# Altium schematic sheet TinyBeacon-144-RevD.SchDoc
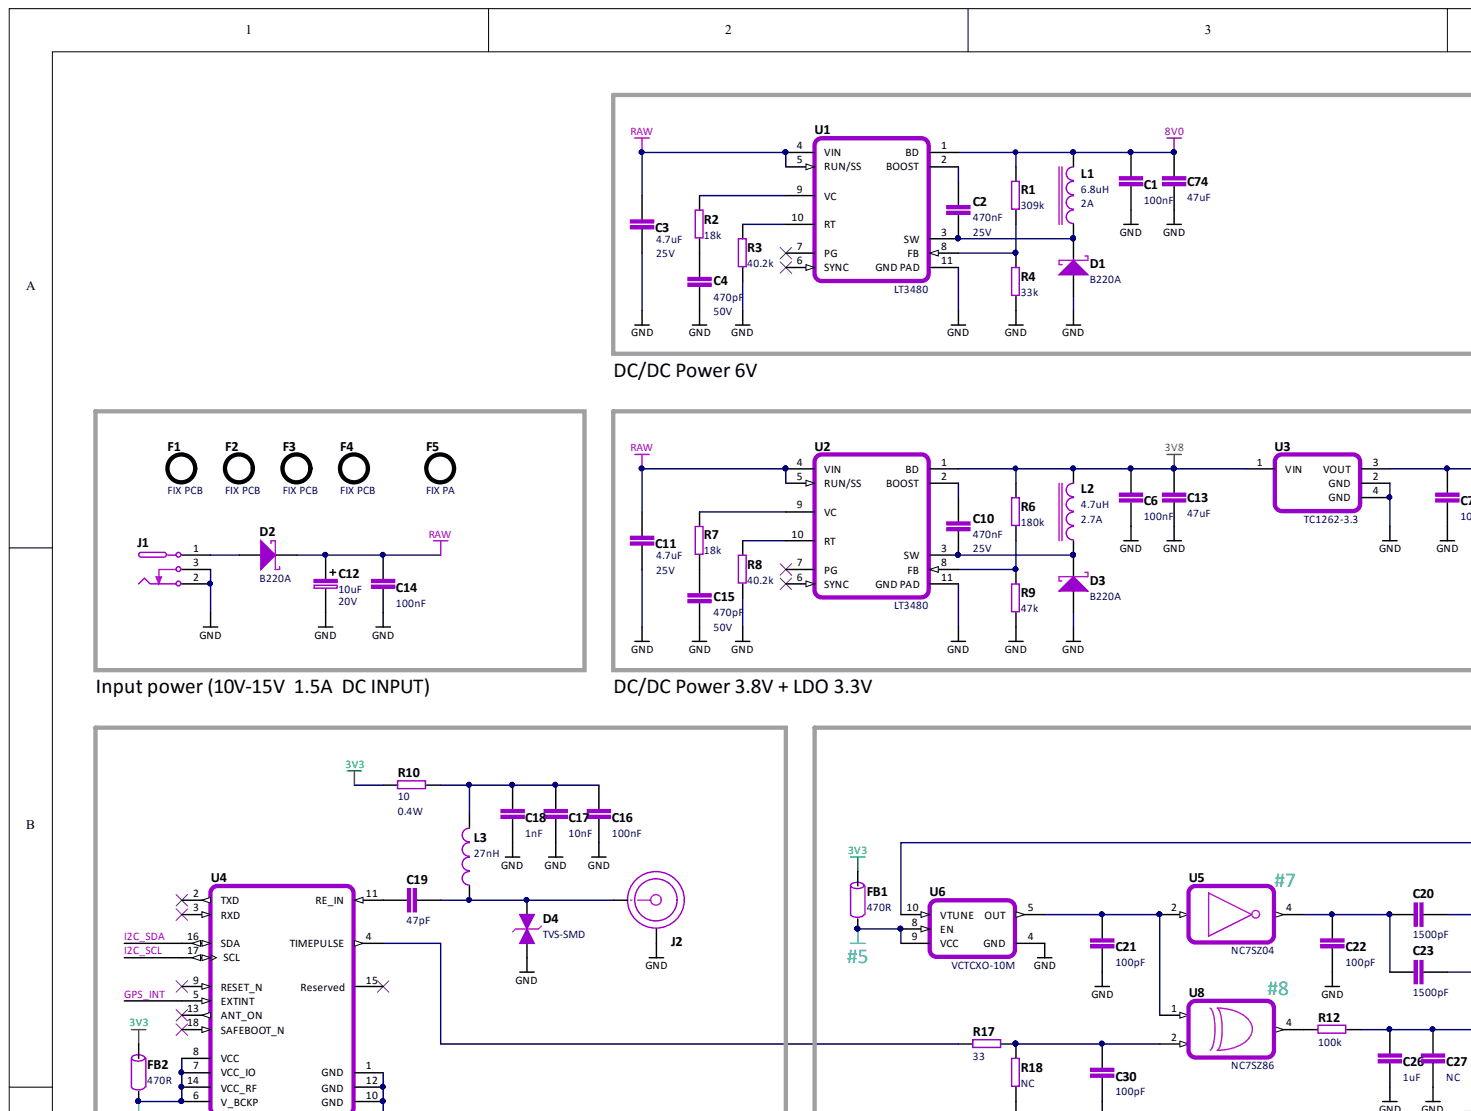
[diagram p0: TinyBeacon-144-RevD.SchDoc, top left]
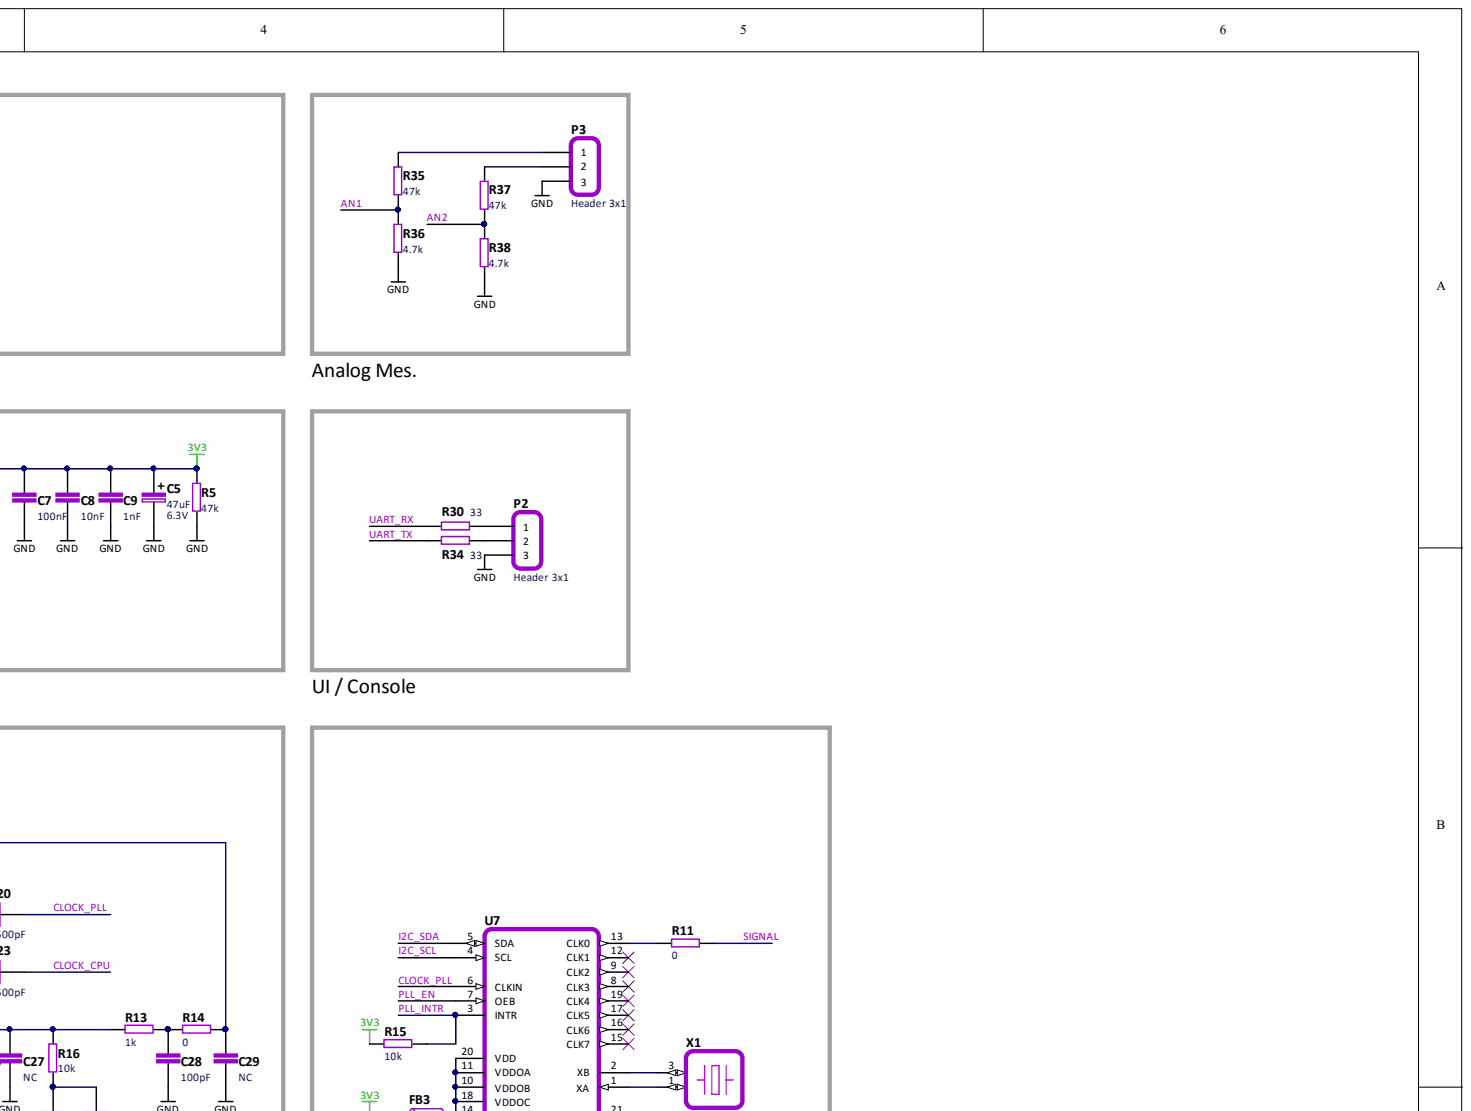
[diagram p1: TinyBeacon-144-RevD.SchDoc, top right]
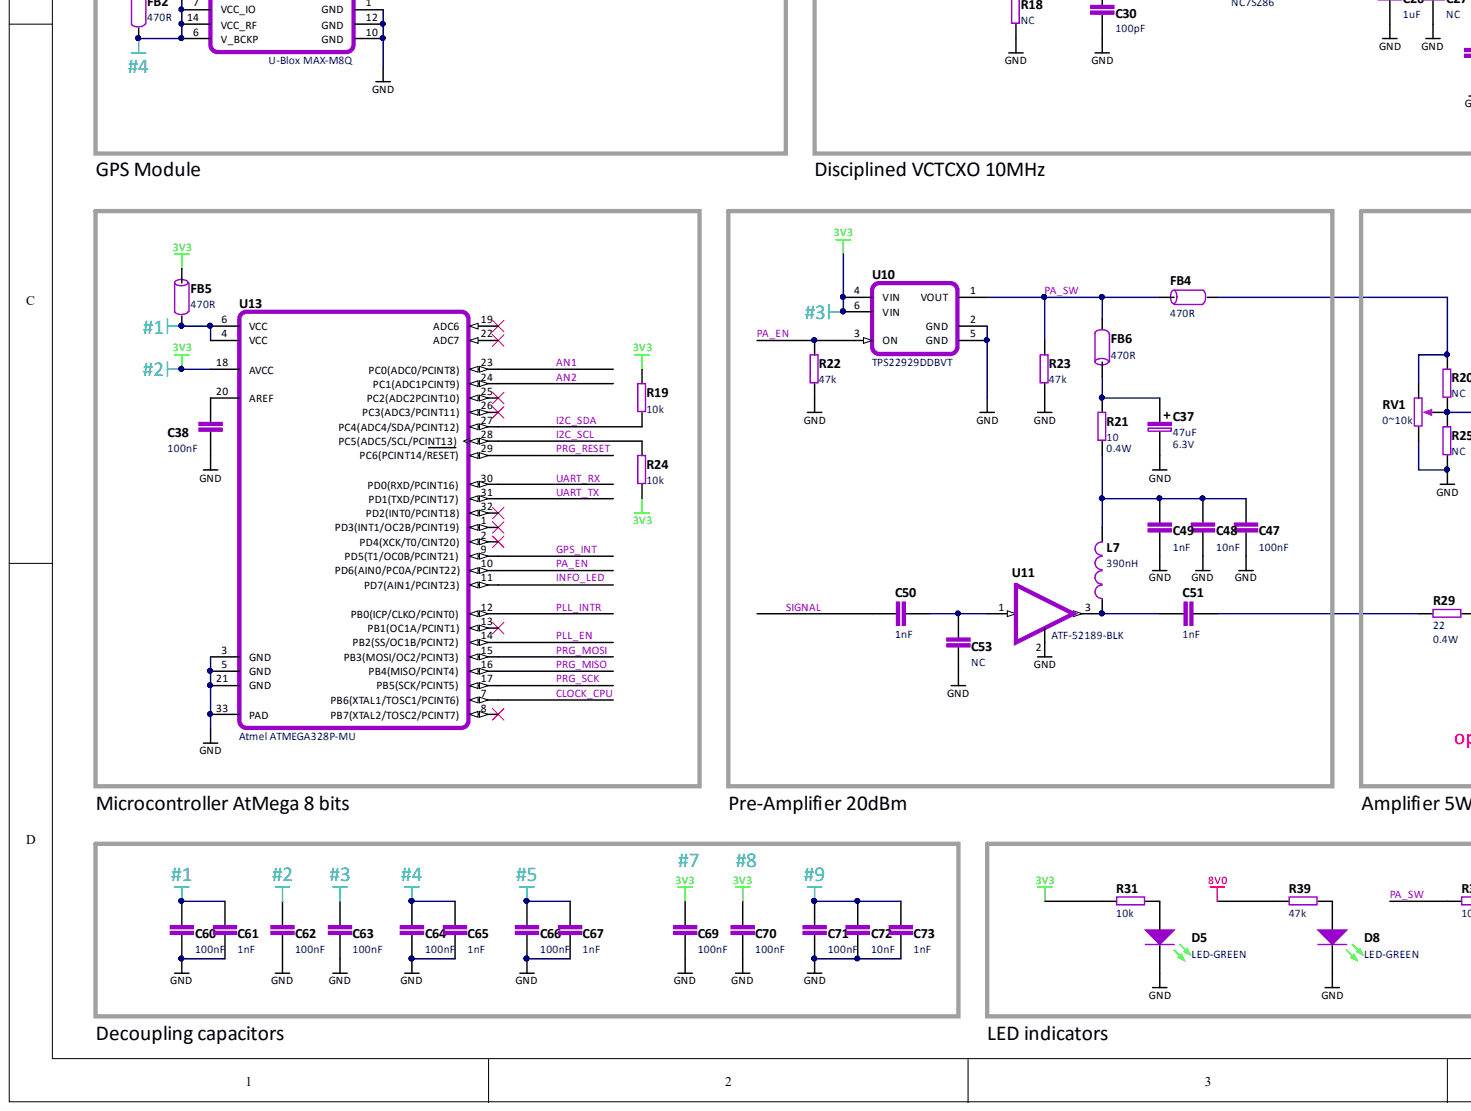
[diagram p2: TinyBeacon-144-RevD.SchDoc, bottom left]
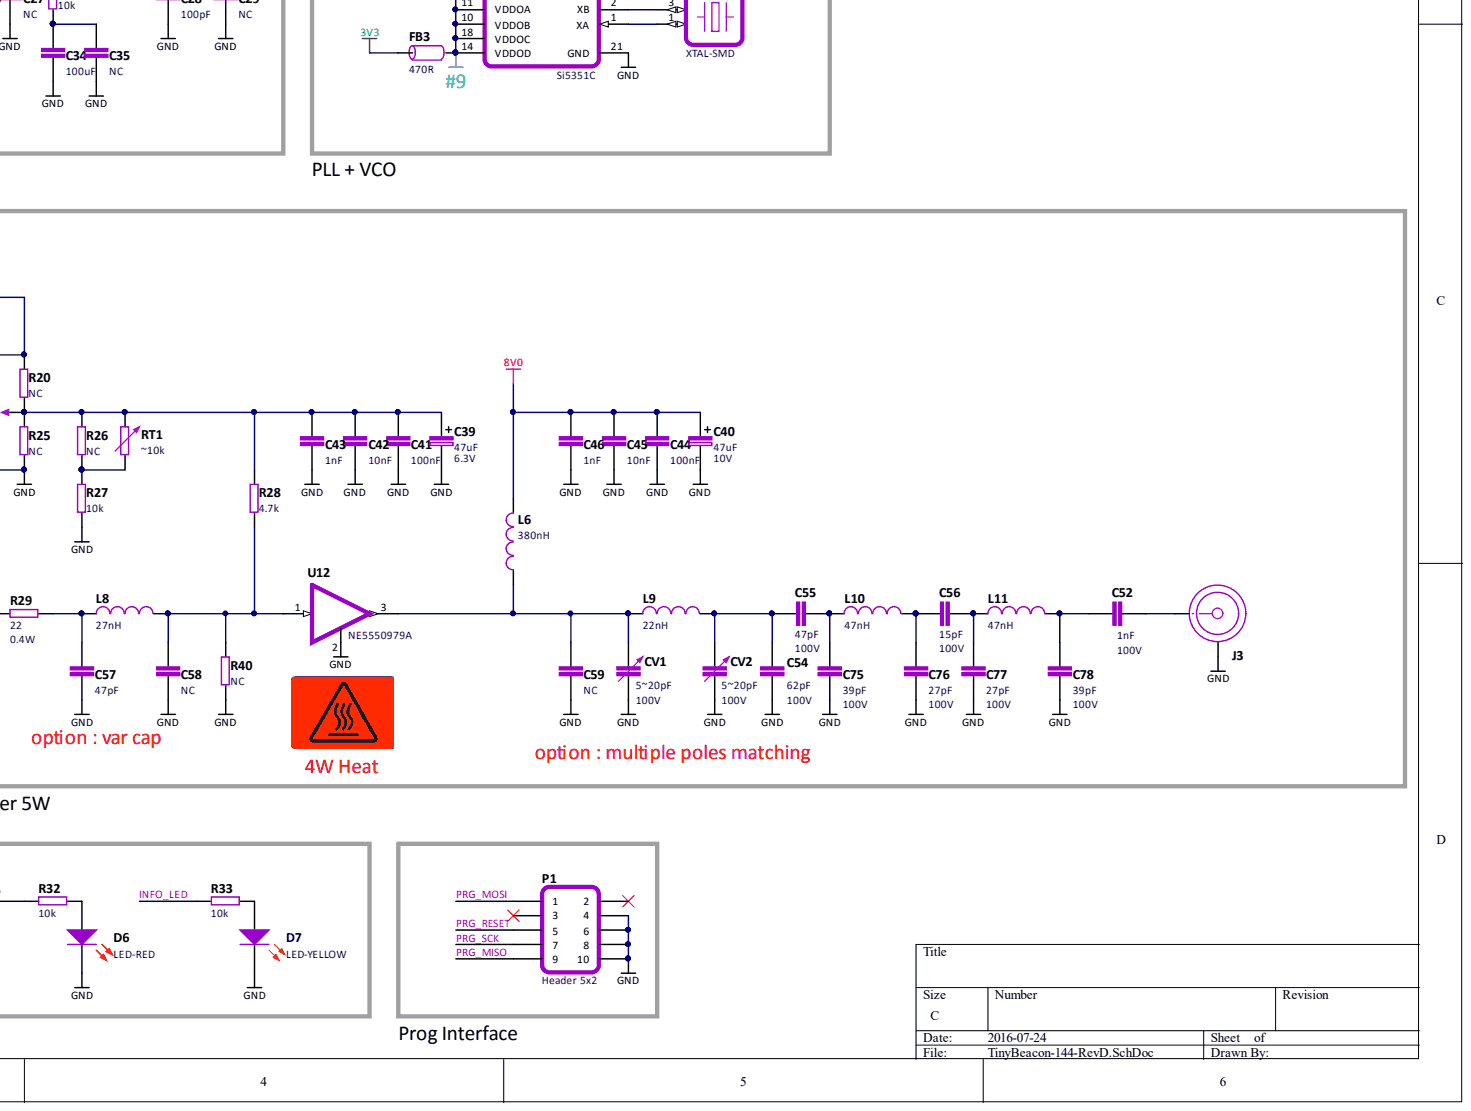
[diagram p3: TinyBeacon-144-RevD.SchDoc, bottom right]

## Parts
C1  100nF  [PCB-1608]
C2  470nF  Rated Voltage: 25V  [PCB-1608]
C3  4.7uF  Rated Voltage: 25V  [PCB-2012]
C4  470pF  Rated Voltage: 50V  [PCB-1608]
C5  47uF  Rated Voltage: 6.3V  [CAP-POL-3216]
C6  100nF  [PCB-1608]
C7  100nF  [PCB-1608]
C8  10nF  [PCB-1608]
C9  1nF  [PCB-1608]
C10  470nF  Rated Voltage: 25V  [PCB-1608]
C11  4.7uF  Rated Voltage: 25V  [PCB-2012]
C12  10uF  Rated Voltage: 20V  [CAP-POL-3216]
C13  47uF  [PCB-3216]
C14  100nF  [PCB-1608]
C15  470pF  Rated Voltage: 50V  [PCB-1608]
C16  100nF  [PCB-1608]
C17  10nF  [PCB-1608]
C18  1nF  [PCB-1608]
C19  47pF  [PCB-1608]
C20  1500pF  [PCB-1608]
C21  100pF  [PCB-1608]
C22  100pF  [PCB-1608]
C23  1500pF  [PCB-1608]
C26  1uF  [PCB-1608]
C27  NC  [PCB-2012]
C28  100pF  [PCB-1608]
C29  NC  [PCB-1608]
C30  100pF  [PCB-1608]
C34  100uF  Rated Current: NC  [PCB-2012]
C35  NC  [PCB-1608]
C37  47uF  Rated Voltage: 6.3V  [CAP-POL-3216]
C38  100nF  [PCB-1608]
C39  47uF  Rated Voltage: 6.3V  [CAP-POL-3216]
C40  47uF  Rated Voltage: 10V  [CAP-POL-3216]
C41  100nF  [PCB-1608]
C42  10nF  [PCB-1608]
C43  1nF  [PCB-1608]
C44  100nF  [PCB-1608]
C45  10nF  [PCB-1608]
C46  1nF  [PCB-1608]
C47  100nF  [PCB-1608]
C48  10nF  [PCB-1608]
C49  1nF  [PCB-1608]
C50  1nF  [PCB-1608]
C51  1nF  [PCB-1608]
C52  1nF  Rated Voltage: 100V  [PCB-1608]
C53  NC  [PCB-1608]
C54  62pF  Rated Voltage: 100V  [PCB-1608]
C55  47pF  [PCB-1608]
C56  15pF  [PCB-1608]
C57  47pF  [PCB-1608]
C58  NC  [PCB-1608]
C59  NC  [PCB-1608]
C60  100nF  [PCB-1608]
C61  1nF  [PCB-1608]
C62  100nF  [PCB-1608]
C63  100nF  [PCB-1608]
C64  100nF  [PCB-1608]
C65  1nF  [PCB-1608]
C66  100nF  [PCB-1608]
C67  1nF  [PCB-1608]
C69  100nF  [PCB-1608]
C70  100nF  [PCB-1608]
C71  100nF  [PCB-1608]
C72  10nF  [PCB-1608]
C73  1nF  [PCB-1608]
C74  47uF  [PCB-3216]
C75  39pF  Rated Voltage: 100V  [PCB-1608]
C76  27pF  Rated Voltage: 100V  [PCB-1608]
C77  27pF  Rated Voltage: 100V  [PCB-1608]
C78  39pF  Rated Voltage: 100V  [PCB-1608]
CV1  5~20pF  Rated Voltage: 100V  [PCB-TZC3]
CV2  5~20pF  Rated Voltage: 100V  [PCB-TZC3]
D1  B220A  [D-SMA]
D2  B220A  [D-SMA]
D3  B220A  [D-SMA]
D4  TVS-SMD  [PCB-1005]
D5  LED-GREEN  [PCB-LED-2012]
D6  LED-RED  [PCB-LED-2012]
D7  LED-YELLOW  [PCB-LED-2012]
D8  LED-GREEN  [PCB-LED-2012]
F1  FIX PCB  Category: PCB  [PCB-FIX-ROUND]
F2  FIX PCB  Category: PCB  [PCB-FIX-ROUND]
F3  FIX PCB  Category: PCB  [PCB-FIX-ROUND]
F4  FIX PCB  Category: PCB  [PCB-FIX-ROUND]
F5  FIX PA  [PCB-FIX-ROUND]
FB1  470R  [PCB-2012]
FB2  470R  [PCB-2012]
FB3  470R  [PCB-2012]
FB4  470R  [PCB-2012]
FB5  470R  [PCB-2012]
FB6  470R  [PCB-2012]
J1  CUI PJ-002AH-SMT-TR  [PCB-CUI-PJ-002AH-SMT]  (value not drawn)
J2  J502 Connector  [PCB-SMA]  (value not drawn)
J3  J502 Connector  [PCB-SMA]  (value not drawn)
L1  6.8uH  Rated Current: 2A  [PCB-5650]
L2  4.7uH  Rated Current: 2.7A  [PCB-5650]
L3  27nH  [PCB-2012]
L6  380nH  [PCB-IND-AIAC-4125]
L7  390nH  [PCB-3216]
L8  27nH  [PCB-2012]
L9  22nH  [PCB-IND-AIAC-1812]
L10  47nH  [PCB-IND-AIAC-1812]
L11  47nH  [PCB-IND-AIAC-1812]
P1  Header 5x2  [PCB-HDR2X5-SMD]
P2  Header 3x1  [PCB-0rhklu08i7n9dxwvdgja-1]
P3  Header 3x1  [PCB-0rhklu08i7n9dxwvdgja-1]
R1  309k  [PCB-1608]
R2  18k  [PCB-1608]
R3  40.2k  [PCB-1608]
R4  33k  [PCB-1608]
R5  47k  [PCB-1608]
R6  180k  [PCB-1608]
R7  18k  [PCB-1608]
R8  40.2k  [PCB-1608]
R9  47k  [PCB-1608]
R10  10  Rated Power: 0.4W  [PCB-2012]
R11  0  [PCB-1608]
R12  100k  [PCB-1608]
R13  1k  [PCB-1608]
R14  0  [PCB-1608]
R15  10k  [PCB-1608]
R16  10k  [PCB-1608]
R17  33  [PCB-1608]
R18  NC  [PCB-1608]
R19  10k  [PCB-1608]
R20  NC  [PCB-1608]
R21  10  Rated Power: 0.4W  [PCB-2012]
R22  47k  [PCB-1608]
R23  47k  [PCB-1608]
R24  10k  [PCB-1608]
R25  NC  [PCB-1608]
R26  NC  [PCB-1608]
R27  10k  [PCB-1608]
R28  4.7k  [PCB-1608]
R29  22  Rated Power: 0.4W  [PCB-2012]
R30  33  [PCB-1608]
R31  10k  [PCB-1608]
R32  10k  [PCB-1608]
R33  10k  [PCB-1608]
R34  33  [PCB-1608]
R35  47k  [PCB-1608]
R36  4.7k  [PCB-1608]
R37  47k  [PCB-1608]
R38  4.7k  [PCB-1608]
R39  47k  [PCB-1608]
R40  NC  [PCB-1608]
RT1  ~10k  [PCB-1608]
RV1  0~10k  [PCB-PVG5]
U1  LT3480  [PCB-LT3480]
U2  LT3480  [PCB-LT3480]
U3  TC1262-3.3  [PCB-SOT-223]
U4  U-Blox MAX-M8Q  Manufacturer PN: MAX-M8Q  [PCB-GPS-MAX8Q]
U5  NC7SZ04  [PCB-SOT-23-5]
U6  VCTCXO-10M  [PCB-TB524]
U7  Si5351C  [PCB-QFN-20]
U8  NC7SZ86  [PCB-SOT-23-5]
U10  TPS22929DDBVT  [PCB-SOT-23-6]
U11  ATF-52189-BLK  [PCB-SOT-89-3]
U12  NE5550979A  [PCB-NE5550979A]
U13  Atmel ATMEGA328P-MU  Manufacturer Name: Atmel  Manufacturer Part Number: ATMEGA328P-MU  [QFN-32]
X1  XTAL-SMD  [PCB-4SMD-32-25]

## Nets
3V8: C6.1 C13.2 L2.2 R6.1 U2.1(BD) U3.1(VIN)
8V0: C1.1 C40.1 C44.1 C45.1 C46.1 C74.2 L1.2 L6.2 R1.1 R39.2 U1.1(BD)
#1: C60.1 C61.1 FB5.1 U13.4(VCC) U13.6(VCC)
#2: C5.1 C7.1 C8.1 C9.1 C62.1 C63.1 C69.1 C70.1 FB1.2 FB2.2 FB3.2 FB5.2 R5.1 R10.2 R15.2 R19.2 R24.1 R31.2 U3.3(VOUT) U5.5 U8.5 U10.4(VIN) U10.6(VIN) U13.18(AVCC)
#4: C64.1 C65.1 FB2.1 U4.6(V_BCKP) U4.7(VCC_IO) U4.8(VCC) U4.14(VCC_RF)
#5: C66.1 C67.1 FB1.1 U6.8(EN) U6.9(VCC)
#9: C71.1 C72.1 C73.1 FB3.1 U7.10(VDDOB) U7.11(VDDOA) U7.14(VDDOD) U7.18(VDDOC) U7.20(VDD)
GND: C1.2 C3.2 C4.2 C5.2 C6.2 C7.2 C8.2 C9.2 C11.2 C12.2 C13.1 C14.2 C15.2 C16.2 C17.2 C18.2 C21.1 C22.1 C26.1 C27.2 C28.1 C29.2 C30.1 C34.1 C35.2 C37.2 C38.1 C39.2 C40.2 C41.2 C42.2 C43.2 C44.2 C45.2 C46.2 C47.2 C48.2 C49.2 C53.2 C54.2 C57.2 C58.2 C59.2 C60.2 C61.2 C62.2 C63.2 C64.2 C65.2 C66.2 C67.2 C69.2 C70.2 C71.2 C72.2 C73.2 C74.1 C75.1 C76.1 C77.1 C78.1 CV1.1 CV2.1 D1.1 D3.1 D4.1 D5.2 D6.2 D7.2 D8.2 F1.1 F2.1 F3.1 F4.1 F5.1 J1.2 J1.3 J2.2 J3.2 P1.4 P1.6 P1.8 P1.10 P2.3 P3.3 R3.2 R4.2 R5.2 R8.2 R9.2 R18.2 R22.1 R23.1 R25.1 R27.1 R36.2 R38.2 R40.1 RV1.1 U1.11(GND_PAD) U2.11(GND_PAD) U3.2(GND) U3.4(GND) U4.1(GND) U4.10(GND) U4.12(GND) U5.3 U6.4(GND) U7.21(GND) U8.3 U10.2(GND) U10.5(GND) U11.2 U12.2 U13.3(GND) U13.5(GND) U13.21(GND) U13.33(PAD) X1.2 X1.4
RAW: C3.1 C11.1 C12.1 C14.1 D2.2 U1.4(VIN) U1.5(RUN/SS) U2.4(VIN) U2.5(RUN/SS)
AN1: R35.2 R36.1 U13.23(PC0(ADC0/PCINT8))
AN2: R37.2 R38.1 U13.24(PC1(ADC1PCINT9))
CLOCK_CPU: C23.2 U13.7(PB6(XTAL1/TOSC1/PCINT6))
CLOCK_PLL: C20.2 U7.6(CLKIN)
GPS_INT: U4.5(EXTINT) U13.9(PD5(T1/OC0B/PCINT21))
I2C_SCL: R24.2 U4.17(SCL) U7.4(SCL) U13.28(PC5(ADC5/SCL/PCINT13))
I2C_SDA: R19.1 U4.16(SDA) U7.5(SDA) U13.27(PC4(ADC4/SDA/PCINT12))
INFO_LED: R33.2 U13.11(PD7(AIN1/PCINT23))
PA_EN: R22.2 U10.3(ON) U13.10(PD6(AIN0/PC0A/PCINT22))
PA_SW: FB4.2 FB6.1 R23.2 R32.2 U10.1(VOUT)
PLL_EN: U7.7(OEB) U13.14(PB2(SS/OC1B/PCINT2))
PLL_INTR: R15.1 U7.3(INTR) U13.12(PB0(ICP/CLKO/PCINT0))
PRG_MISO: P1.9 U13.16(PB4(MISO/PCINT4))
PRG_MOSI: P1.1 U13.15(PB3(MOSI/OC2/PCINT3))
PRG_RESET: P1.5 U13.29(PC6(PCINT14/RESET))
PRG_SCK: P1.7 U13.17(PB5(SCK/PCINT5))
SIGNAL: C50.2 R11.1
UART_RX: R30.2 U13.30(PD0(RXD/PCINT16))
UART_TX: R34.2 U13.31(PD1(TXD/PCINT17))
NetC2_1: C2.1 U1.2(BOOST)
NetC2_2: C2.2 D1.2 L1.1 U1.3(SW)
NetC4_1: C4.1 R2.2
NetC10_1: C10.1 U2.2(BOOST)
NetC10_2: C10.2 D3.2 L2.1 U2.3(SW)
NetC15_1: C15.1 R7.2
NetC16_1: C16.1 C17.1 C18.1 L3.2 R10.1
NetC19_1: C19.1 U4.11(RE_IN)
NetC19_2: C19.2 D4.2 J2.1 L3.1
NetC20_1: C20.1 C22.2 C23.1 U5.4
NetC21_2: C21.2 U5.2 U6.5(OUT) U8.1
NetC26_2: C26.2 C27.1 R12.1 R13.2 R16.1
NetC28_2: C28.2 R13.1 R14.2
NetC29_1: C29.1 R14.1 U6.10(VTUNE)
NetC30_2: C30.2 R17.2 R18.1 U8.2
NetC34_2: C34.2 C35.1 R16.2
NetC37_1: C37.1 FB6.2 R21.2
NetC38_2: C38.2 U13.20(AREF)
NetC39_1: C39.1 C41.1 C42.1 C43.1 R20.1 R25.2 R26.2 R28.2 RT1.2 RV1.2
NetC47_1: C47.1 C48.1 C49.1 L7.2 R21.1
NetC50_1: C50.1 C53.1 U11.1
NetC51_1: C51.1 R29.2
NetC51_2: C51.2 L7.1 U11.3
NetC52_1: C52.1 J3.1
NetC52_2: C52.2 C78.2 L11.2
NetC54_1: C54.1 C55.1 CV2.2 L9.2
NetC55_2: C55.2 C75.2 L10.1
NetC56_1: C56.1 C77.2 L11.1
NetC56_2: C56.2 C76.2 L10.2
NetC57_1: C57.1 L8.1 R29.1
NetC58_1: C58.1 L8.2 R28.1 R40.2 U12.1
NetC59_1: C59.1 CV1.2 L6.1 L9.1 U12.3
NetD2_1: D2.1 J1.1
NetD5_1: D5.1 R31.1
NetD6_1: D6.1 R32.1
NetD7_1: D7.1 R33.1
NetD8_1: D8.1 R39.1
NetFB4_1: FB4.1 R20.2 RV1.3
NetP2_1: P2.1 R30.1
NetP2_2: P2.2 R34.1
NetP3_1: P3.1 R35.1
NetP3_2: P3.2 R37.1
NetR1_2: R1.2 R4.1 U1.8(FB)
NetR2_1: R2.1 U1.9(VC)
NetR3_1: R3.1 U1.10(RT)
NetR6_2: R6.2 R9.1 U2.8(FB)
NetR7_1: R7.1 U2.9(VC)
NetR8_1: R8.1 U2.10(RT)
NetR11_2: R11.2 U7.13(CLK0)
NetR12_2: R12.2 U8.4
NetR17_1: R17.1 U4.4(TIMEPULSE)
NetR26_1: R26.1 R27.2 RT1.1
NetU7_1: U7.1(XA) X1.1
NetU7_2: U7.2(XB) X1.3

Not connected: P1 2 3; U1 6(SYNC) 7(PG); U2 6(SYNC) 7(PG); U4 2(TXD) 3(RXD) 9(RESET_N) 13(ANT_ON) 15(Reserved) 18(SAFEBOOT_N); U7 8(CLK3) 9(CLK2) 12(CLK1) 15(CLK7) 16(CLK6) 17(CLK5) 19(CLK4); U13 1(PD3(INT1/OC2B/PCINT19)) 2(PD4(XCK/T0/CINT20)) 8(PB7(XTAL2/TOSC2/PCINT7)) 13(PB1(OC1A/PCINT1)) 19(ADC6) 22(ADC7) 25(PC2(ADC2PCINT10)) 26(PC3(ADC3/PCINT11)) 32(PD2(INT0/PCINT18))
